# Altium schematic sheet ZYNQ_PS_BANK.SchDoc
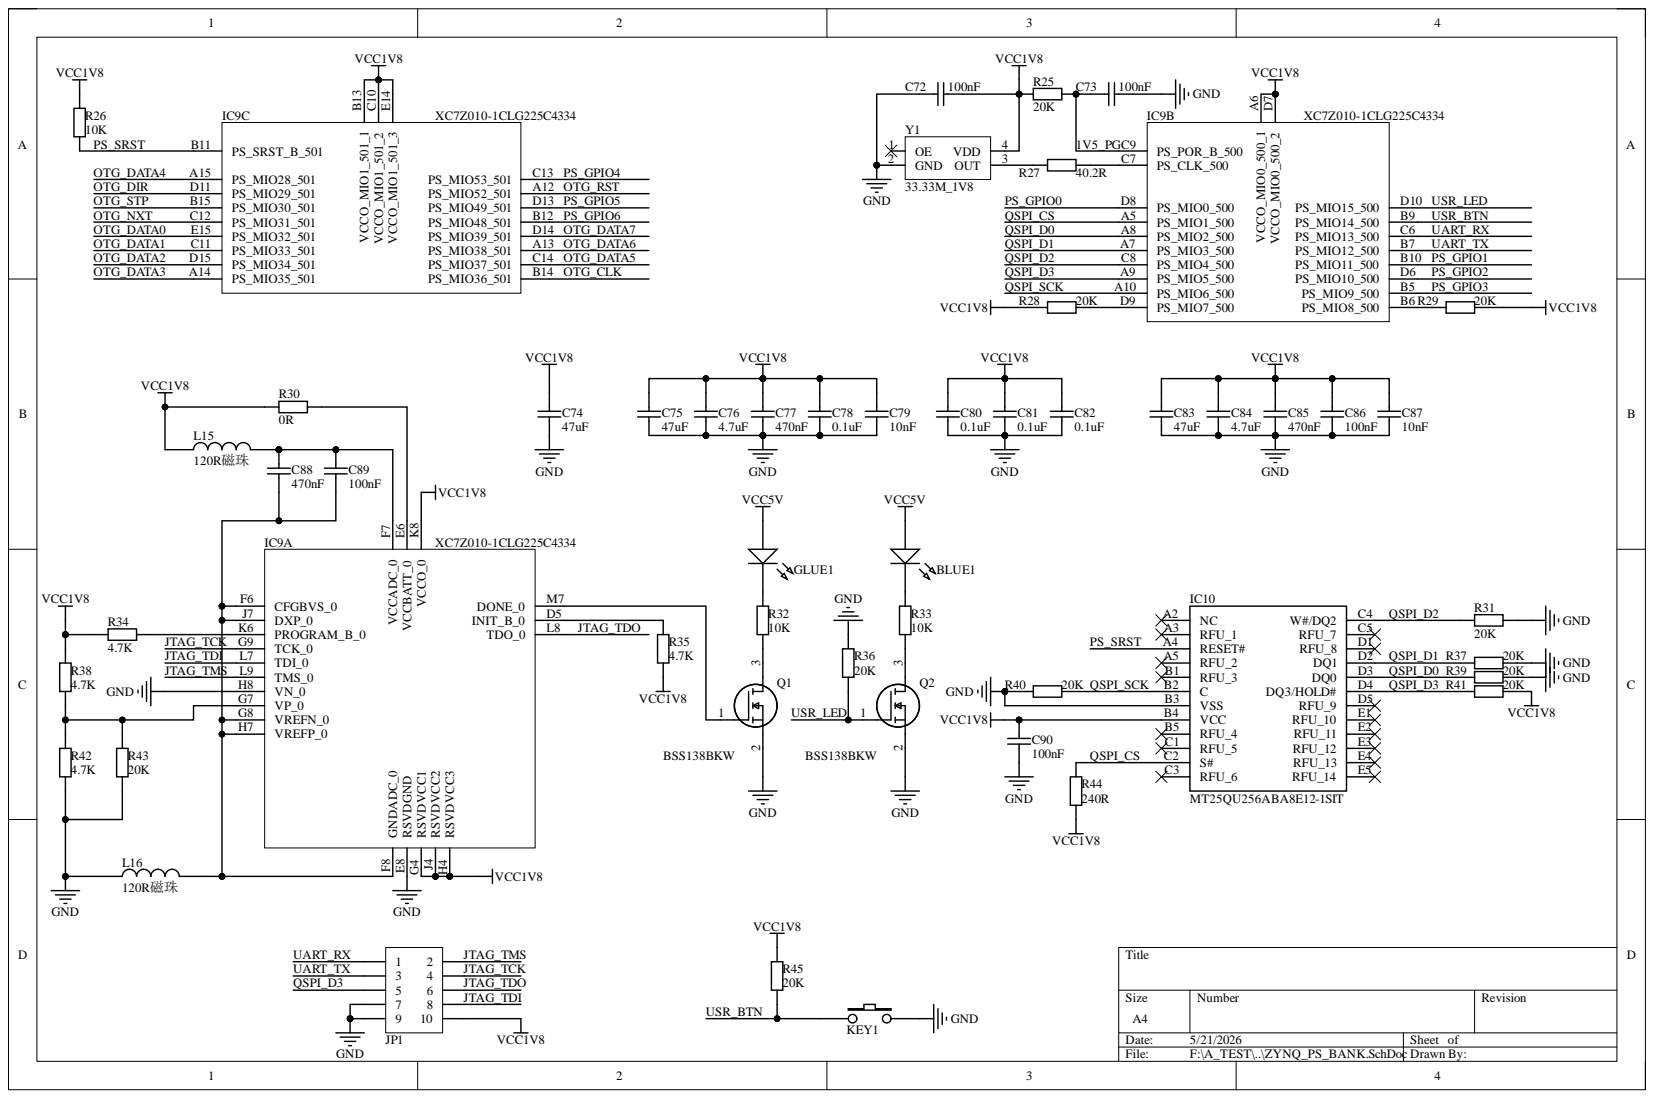
[diagram p0: ZYNQ_PS_BANK.SchDoc]

## Parts
BLUE1  LED  [LED 0402 B]  (value not drawn)
C72  100nF  [C 0402_L]
C73  100nF  [C 0402_L]
C74  47uF  [C 0805_L]
C75  47uF  [C 0805_L]
C76  4.7uF  [C 0603_L]
C77  470nF  [C 0402_L]
C78  0.1uF  [C 0402_L]
C79  10nF  [C 0402_L]
C80  0.1uF  [C 0402_L]
C81  0.1uF  [C 0402_L]
C82  0.1uF  [C 0402_L]
C83  47uF  [C 0805_L]
C84  4.7uF  [C 0603_L]
C85  470nF  [C 0402_L]
C86  100nF  [C 0402_L]
C87  10nF  [C 0402_L]
C88  470nF  [C 0402_L]
C89  100nF  [C 0402_L]
C90  100nF  [C 0402_L]
GLUE1  LED  [LED 0402 G]  (value not drawn)
IC9  XC7Z010-1CLG225C4334  [BGA225C80P15X15_1300X1300X150]  (parts A,B,C)
IC10  MT25QU256ABA8E12-1SIT  [BGA24C100P5X5_600X800X120]
JP1  Header 5X2  [HDR2.54-LI-2x5P]  (value not drawn)
KEY1  按键  [TSW 3*6*4.3-S-W]  (value not drawn)
L15  120R磁珠  [L 0402]
L16  120R磁珠  [L 0402]
Q1  BSS138BKW  [SOT65P210X110-3N]
Q2  BSS138BKW  [SOT65P210X110-3N]
R25  20K  [R 0402_L]
R26  10K  [R 0402_L]
R27  40.2R  [R 0402_L]
R28  20K  [R 0402_L]
R29  20K  [R 0402_L]
R30  0R  [R 0402_L]
R31  20K  [R 0402_L]
R32  10K  [R 0402_L]
R33  10K  [R 0402_L]
R34  4.7K  [R 0402_L]
R35  4.7K  [R 0402_L]
R36  20K  [R 0402_L]
R37  20K  [R 0402_L]
R38  4.7K  [R 0402_L]
R39  20K  [R 0402_L]
R40  20K  [R 0402_L]
R41  20K  [R 0402_L]
R42  4.7K  [R 0402_L]
R43  20K  [R 0402_L]
R44  240R  [R 0402_L]
R45  20K  [R 0402_L]
Y1  33.3M  value 33.33M_1V8  [OSC 3225-4P]

## Nets
GND: C72.1 C73.2 C74.1 C75.1 C76.1 C77.1 C78.1 C79.1 C80.1 C81.1 C82.1 C83.1 C84.1 C85.1 C86.1 C87.1 C90.2 IC9.E8(RSVDGND) IC9.H8(VN_0) IC10.B3(VSS) JP1.7 JP1.9 KEY1.2 L16.1 Q1.2 Q2.2 R31.1 R36.2 R37.1 R39.1 R40.2 R42.2 R43.2 Y1.2(GND)
VCC1V8: C72.2 C74.2 C75.2 C76.2 C77.2 C78.2 C79.2 C80.2 C81.2 C82.2 C83.2 C84.2 C85.2 C86.2 C87.2 C90.1 IC9.A6(VCCO_MIO0_500_1) IC9.B13(VCCO_MIO1_501_1) IC9.C10(VCCO_MIO1_501_2) IC9.D7(VCCO_MIO0_500_2) IC9.E14(VCCO_MIO1_501_3) IC9.G4(RSVDVCC1) IC9.H4(RSVDVCC3) IC9.J4(RSVDVCC2) IC9.K8(VCCO_0) IC10.B4(VCC) JP1.10 L15.1 R25.1 R26.2 R28.1 R29.2 R30.2 R34.1 R35.2 R38.1 R41.1 R44.2 R45.2 Y1.4(VDD)
VCC5V: BLUE1.1 GLUE1.1
1V5_PG: C73.1 IC9.C9(PS_POR_B_500) R25.2
JTAG_TCK: IC9.G9(TCK_0) JP1.4
JTAG_TDI: IC9.L7(TDI_0) JP1.8
JTAG_TDO: IC9.L8(TDO_0) JP1.6
JTAG_TMS: IC9.L9(TMS_0) JP1.2
OTG_CLK: IC9.B14(PS_MIO36_501)
OTG_DATA0: IC9.E15(PS_MIO32_501)
OTG_DATA1: IC9.C11(PS_MIO33_501)
OTG_DATA2: IC9.D15(PS_MIO34_501)
OTG_DATA3: IC9.A14(PS_MIO35_501)
OTG_DATA4: IC9.A15(PS_MIO28_501)
OTG_DATA5: IC9.C14(PS_MIO37_501)
OTG_DATA6: IC9.A13(PS_MIO38_501)
OTG_DATA7: IC9.D14(PS_MIO39_501)
OTG_DIR: IC9.D11(PS_MIO29_501)
OTG_NXT: IC9.C12(PS_MIO31_501)
OTG_RST: IC9.A12(PS_MIO52_501)
OTG_STP: IC9.B15(PS_MIO30_501)
PS_GPIO0: IC9.D8(PS_MIO0_500)
PS_GPIO1: IC9.B10(PS_MIO11_500)
PS_GPIO2: IC9.D6(PS_MIO10_500)
PS_GPIO3: IC9.B5(PS_MIO9_500)
PS_GPIO4: IC9.C13(PS_MIO53_501)
PS_GPIO5: IC9.D13(PS_MIO49_501)
PS_GPIO6: IC9.B12(PS_MIO48_501)
PS_SRST: IC9.B11(PS_SRST_B_501) IC10.A4(RESET#) R26.1
QSPI_CS: IC9.A5(PS_MIO1_500) IC10.C2(S#) R44.1
QSPI_D0: IC9.A8(PS_MIO2_500) IC10.D3(DQ0) R39.2
QSPI_D1: IC9.A7(PS_MIO3_500) IC10.D2(DQ1) R37.2
QSPI_D2: IC9.C8(PS_MIO4_500) IC10.C4(W#/DQ2) R31.2
QSPI_D3: IC9.A9(PS_MIO5_500) IC10.D4(DQ3/HOLD#) JP1.5 R41.2
QSPI_SCK: IC9.A10(PS_MIO6_500) IC10.B2(C) R40.1
UART_RX: IC9.C6(PS_MIO13_500) JP1.1
UART_TX: IC9.B7(PS_MIO12_500) JP1.3
USR_BTN: IC9.B9(PS_MIO14_500) KEY1.1 R45.1
USR_LED: IC9.D10(PS_MIO15_500) Q2.1 R36.1
NetBLUE1_2: BLUE1.2 R33.2
NetC88_1: C88.1 C89.1 IC9.F6(CFGBVS_0) IC9.F8(GNDADC_0) IC9.G8(VREFN_0) IC9.H7(VREFP_0) IC9.J7(DXP_0) L16.2
NetC88_2: C88.2 C89.2 IC9.F7(VCCADC_0) L15.2
NetGLUE1_2: GLUE1.2 R32.2
NetIC9_B6: IC9.B6(PS_MIO8_500) R29.1
NetIC9_C7: IC9.C7(PS_CLK_500) R27.1
NetIC9_D5: IC9.D5(INIT_B_0) R35.1
NetIC9_D9: IC9.D9(PS_MIO7_500) R28.2
NetIC9_E6: IC9.E6(VCCBATT_0) R30.1
NetIC9_G7: IC9.G7(VP_0) R38.2 R42.1 R43.1
NetIC9_K6: IC9.K6(PROGRAM_B_0) R34.2
NetIC9_M7: IC9.M7(DONE_0) Q1.1
NetQ1_3: Q1.3 R32.1
NetQ2_3: Q2.3 R33.1
NetR27_2: R27.2 Y1.3(OUT)

Not connected: IC10 A2(NC) A3(RFU_1) A5(RFU_2) B1(RFU_3) B5(RFU_4) C1(RFU_5) C3(RFU_6) C5(RFU_7) D1(RFU_8) D5(RFU_9) E1(RFU_10) E2(RFU_11) E3(RFU_12) E4(RFU_13) E5(RFU_14); Y1 1(OE)

## Library data of the parts
C72 C73 C90 = Cap1: Component Kind: Standard; value: 100nF; Library Name: 零散元件库2.SCHLIB
C74-C89 = Cap: 贴片电容; Component Kind: Standard; Library Name: A_Schlib.SchLib
  C74 C75 C83: value: 47uF
  C76 C84: value: 4.7uF
  C77 C85 C88: value: 470nF
  C78 C80-C82: value: 0.1uF
  C79 C87: value: 10nF
  C86 C89: value: 100nF
IC9 = XC7Z010-1CLG225C4334: Integrated Circuit; Manufacturer_Name: AMD; Component Kind: Standard; Datasheet Link: https://docs.amd.com/api/khub/documents/kOAtMqkVamwUDaIfCXO91A/content?Ft-Calling-App=ft%2Fturnkey-portal&Ft-Calling-App-Version=5.2.5#; Height: 1.5mm; Library Name: SamacSys.SchLib; Manufacturer_Part_Number: XC7Z010-1CLG225C4334
IC10 = MT25QU256ABA8E12-1SIT: Integrated Circuit; Manufacturer_Name: Micron; Arrow Part Number: MT25QU256ABA8E12-1SIT; Arrow Price/Stock: https://www.arrow.com/en/products/mt25qu256aba8e12-1sit/micron-technology; Component Kind: Standard; Datasheet Link: https://datasheet.datasheetarchive.com/originals/distributors/DKDS42/DSANUWW0045434.pdf; Height: 1.2mm; Library Name: SamacSys.SchLib; Manufacturer_Part_Number: MT25QU256ABA8E12-1SIT; Mouser Part Number: 340-256467-TRAY; Mouser Price/Stock: https://www.mouser.co.uk/ProductDetail/Micron/MT25QU256ABA8E12-1SIT?qs=rrS6PyfT74fSFf5qgR3KQw%3D%3D
JP1 = Header 5X2: 5*2P接插件; 版权归属: 封装库来自淘宝 ID：黄晶宝石; BOM名称: 5*2P接插件; Component Kind: Standard; 学习资源: https://shop463601444.taobao.com; Library Name: 2.54间距排针排母插件贴片.SchLib
KEY1 = 按键: 常用; Component Kind: Standard; Library Name: A_Schlib.SchLib
L15 L16 = 电感: 包含功率; Component Kind: Standard; Library Name: A_Schlib.SchLib
Q1 Q2 = BSS138BKW,115: MOSFET (N-Channel); Manufacturer_Name: Nexperia; Arrow Part Number: BSS138BKW,115; Arrow Price/Stock: https://www.arrow.com/en/products/bss138bkw115/nexperia?utm_currency=USD&region=nac; Component Kind: Standard; Datasheet Link: https://assets.nexperia.com/documents/data-sheet/BSS138BKW.pdf; Height: 1.1mm; Library Name: SamacSys.SchLib; Manufacturer_Part_Number: BSS138BKW,115; Mouser Part Number: 771-BSS138BKW115; Mouser Price/Stock: https://www.mouser.co.uk/ProductDetail/Nexperia/BSS138BKW115?qs=A1cBxND5mHJ1Grk7RQmcgg%3D%3D
R25 R27-R29 = Res_1: Component Kind: Standard; Library Name: 零散元件库2.SCHLIB
  R25 R28 R29: value: 20K
  R27: value: 40.2R
R26 R30 R34 R35 R38 R42 R43 = Res: 通用; Component Kind: Standard; Library Name: A_Schlib.SchLib
  R26: value: 10K
  R30: value: 0R
  R34 R35 R38 R42: value: 4.7K
  R43: value: 20K
R31-R33 R36 R37 R39-R41 R44 R45 = Res: Component Kind: Standard; Library Name: 零散元件库2.SCHLIB
  R31 R36 R37 R39-R41 R45: value: 20K
  R32 R33: value: 10K
  R44: value: 240R
Y1 = XATLS: 贴片有源晶振; Component Kind: Standard; Library Name: 2.0 - 保险丝-晶振-光耦.SchLib; 封装库来自淘宝卖家 ID：黄晶宝石: https://shop463601444.taobao.com
BLUE1 GLUE1 = LED: Component Kind: Standard; Library Name: 零散元件-V1.1.SCHLIB
